# Altium schematic sheet S06_Metering_RevF.SchDoc
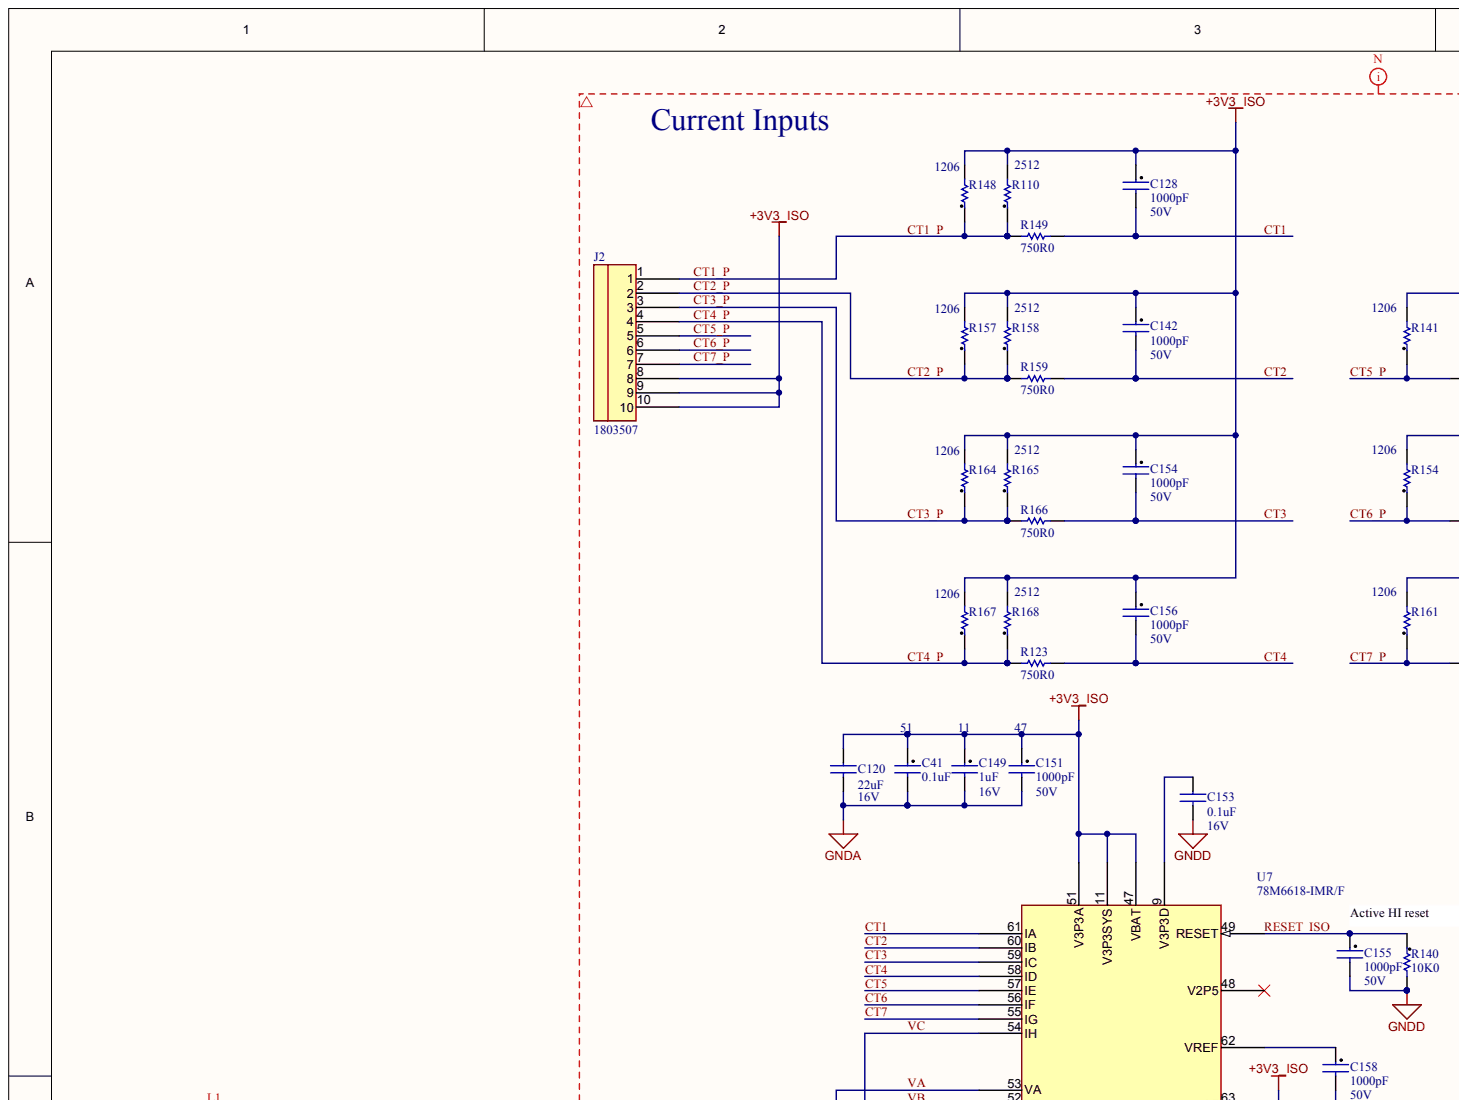
[diagram p0: S06_Metering_RevF.SchDoc, top left]
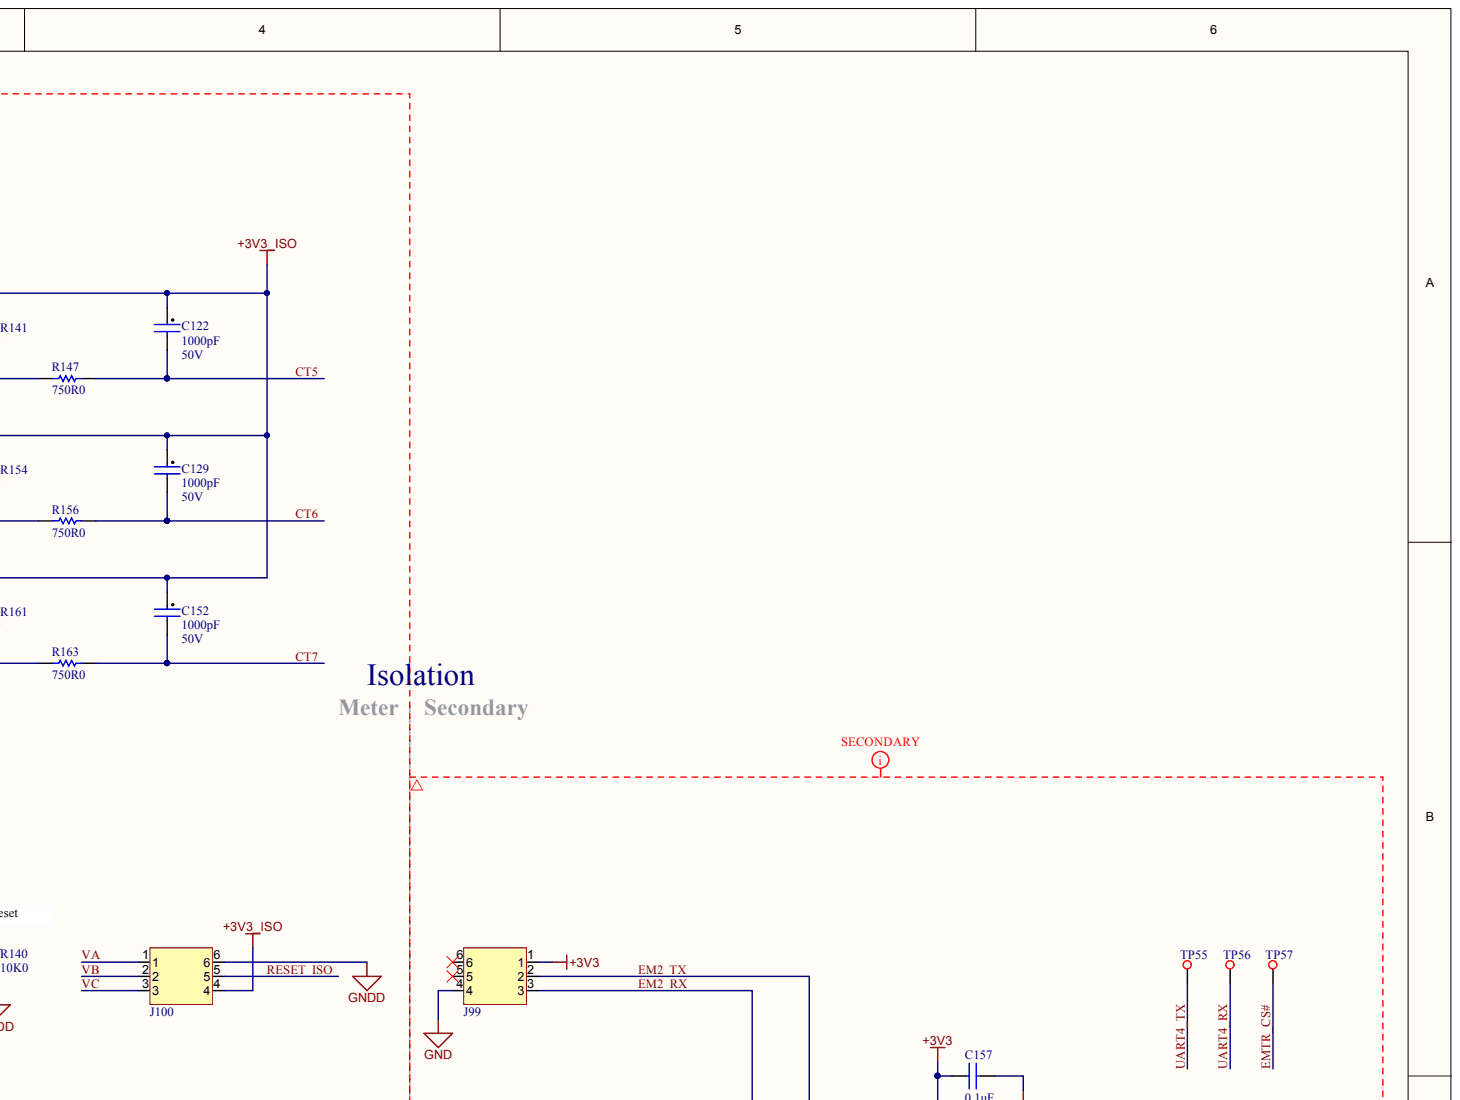
[diagram p1: S06_Metering_RevF.SchDoc, top right]
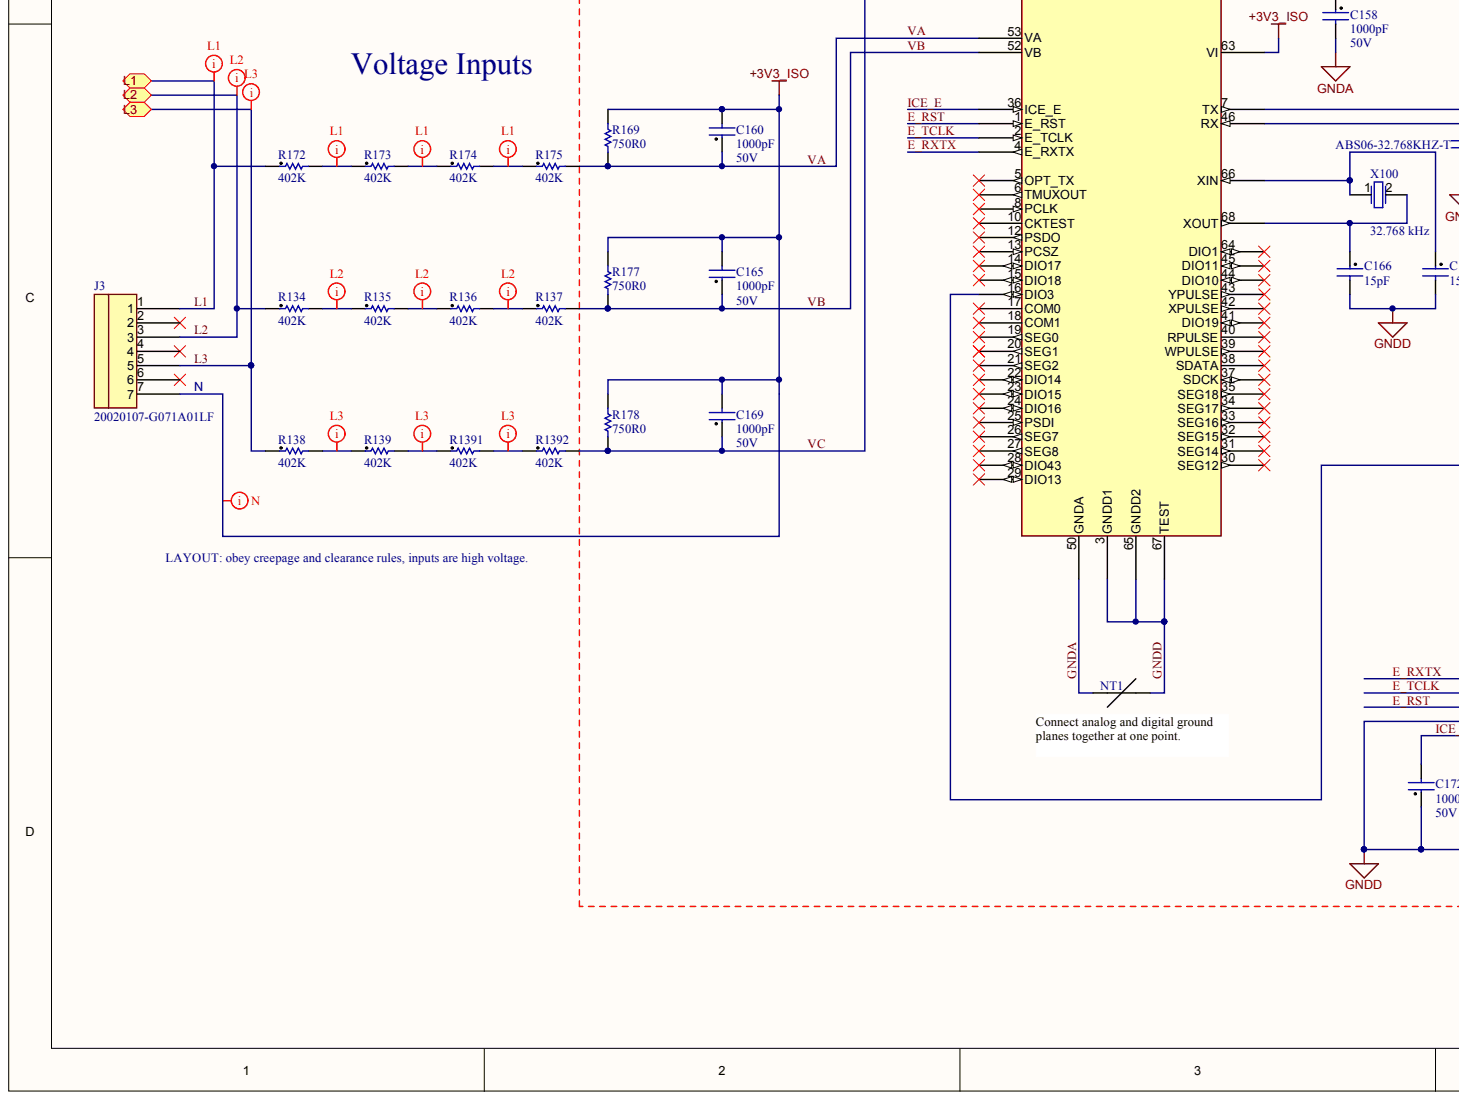
[diagram p2: S06_Metering_RevF.SchDoc, bottom left]
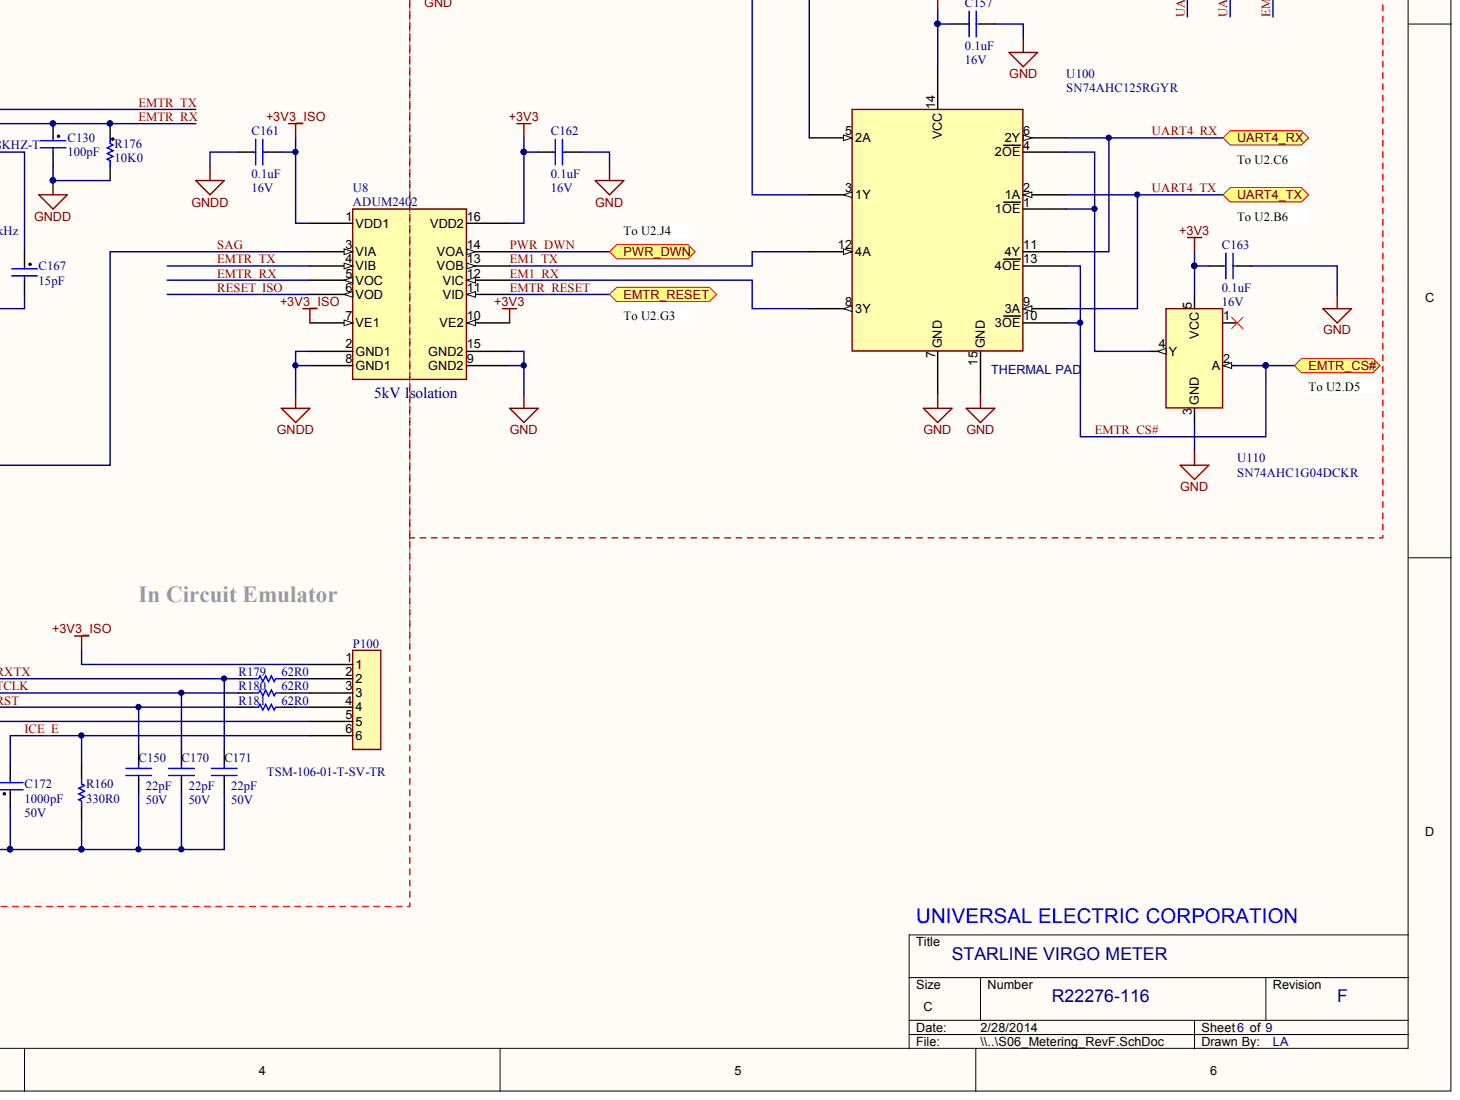
[diagram p3: S06_Metering_RevF.SchDoc, bottom right]

## Parts
C41  0.1uF
C120  Voltage: 16V  (hidden comment: 22uF)
C122  Voltage: 50V  (hidden comment: 1000pF)
C128  Voltage: 50V  (hidden comment: 1000pF)
C129  Voltage: 50V  (hidden comment: 1000pF)
C130  100pF
C142  Voltage: 50V  (hidden comment: 1000pF)
C149  Voltage-Rated: 16V  (hidden comment: 1uF)
C150  Voltage: 50V  (hidden comment: 22pF)
C151  Voltage: 50V  (hidden comment: 1000pF)
C152  Voltage: 50V  (hidden comment: 1000pF)
C153  value 0.1uF  Voltage: 16V  (hidden comment: CAP CER 0.1uF X5R 10% 16V SM_0402)
C154  Voltage: 50V  (hidden comment: 1000pF)
C155  Voltage: 50V  (hidden comment: 1000pF)
C156  Voltage: 50V  (hidden comment: 1000pF)
C157  value 0.1uF  (hidden comment: CAP CER 0.1uF X5R 10% 16V SM_0402)
C158  Voltage: 50V  (hidden comment: 1000pF)
C160  Voltage: 50V  (hidden comment: 1000pF)
C161  value 0.1uF  Voltage: 16V  (hidden comment: CAP CER 0.1uF X5R 10% 16V SM_0402)
C162  value 0.1uF  Voltage: 16V  (hidden comment: CAP CER 0.1uF X5R 10% 16V SM_0402)
C163  value 0.1uF  (hidden comment: CAP CER 0.1uF X5R 10% 16V SM_0402)
C165  Voltage: 50V  (hidden comment: 1000pF)
C166  15pF
C167  15pF
C169  Voltage: 50V  (hidden comment: 1000pF)
C170  Voltage: 50V  (hidden comment: 22pF)
C171  Voltage: 50V  (hidden comment: 22pF)
C172  Voltage: 50V  (hidden comment: 1000pF)
J2  value 1803507  MFG_PART_NO: 1803507  (hidden comment: TERM BLOCK PLUGGABLE 3.81MM 10P)
J3  20020107-G071A01LF
J99  A3A-6DA-2SV(71)  (value not drawn)
J100  A3A-6DA-2SV(71)  (value not drawn)
NT1  Net Tie  (value not drawn)
P100  TSM-106-01-T-SV-TR
R110  Package: 2512
R123  value 750R0  (hidden comment: RES 750R0 1/10W 1% SM_0603)
R134  402K
R135  402K
R136  402K
R137  402K
R138  402K
R139  402K
R140  10K0
R141  Package: 1206  (hidden comment: 5R76)
R147  value 750R0  (hidden comment: RES 750R0 1/10W 1% SM_0603)
R148  Package: 1206  (hidden comment: 5R76)
R149  value 750R0  (hidden comment: RES 750R0 1/10W 1% SM_0603)
R154  Package: 1206  (hidden comment: 5R76)
R156  value 750R0  (hidden comment: RES 750R0 1/10W 1% SM_0603)
R157  Package: 1206  (hidden comment: 5R76)
R158  Package: 2512
R159  value 750R0  (hidden comment: RES 750R0 1/10W 1% SM_0603)
R160  330R0
R161  Package: 1206  (hidden comment: 5R76)
R163  value 750R0  (hidden comment: RES 750R0 1/10W 1% SM_0603)
R164  Package: 1206  (hidden comment: 5R76)
R165  Package: 2512
R166  value 750R0  (hidden comment: RES 750R0 1/10W 1% SM_0603)
R167  Package: 1206  (hidden comment: 5R76)
R168  Package: 2512
R169  750R0
R172  402K
R173  402K
R174  402K
R175  402K
R176  10K0
R177  750R0
R178  750R0
R179  value 62R0
R180  value 62R0
R181  value 62R0
R1391  402K
R1392  402K
TP55  =VALUE
TP56  =VALUE
TP57  =VALUE
U7  value 78M6618-IMR/F  MFG_PART_NO: 78M6618-IMR/F  TYPE: IC  [QFN40P800X800X85-68N]  (hidden comment: Meter IC 78M6618-IMR/F)
U8  ADUM2402
U100  SN74AHC125RGYR
U110  SN74AHC1G04DCKR
X100  32.768 kHz

## Ports
EMTR_CS#, EMTR_RESET, L1, L2, L3, PWR_DWN, UART4_RX, UART4_TX

## Nets
+3V3: C157.1 C162.1 C163.1 J99.1 U8.10(VE2) U8.16(VDD2) U100.14(VCC) U110.5(VCC)
+3V3_ISO: C41.1 C120.1 C122.1 C128.1 C129.1 C142.1 C149.1 C151.1 C152.1 C154.1 C156.1 C160.2 C161.2 C165.2 C169.2 J2.8 J2.9 J2.10 J3.7 J100.4 P100.1 R110.2 R141.2 R148.2 R154.2 R157.2 R158.2 R161.2 R164.2 R165.2 R167.2 R168.2 R169.1 R177.1 R178.1 U7.11(V3P3SYS) U7.47(VBAT) U7.51(V3P3A) U7.63(VI) U8.1(VDD1) U8.7(VE1)
GND: C157.2 C162.2 C163.2 J99.4 U8.9(GND2) U8.15(GND2) U100.7(GND) U100.15(GND) U110.3(GND)
CT1: C128.2 R149.1 U7.61(IA)
CT1_P: J2.1 R110.1 R148.1 R149.2
CT2: C142.2 R159.1 U7.60(IB)
CT2_P: J2.2 R157.1 R158.1 R159.2
CT3: C154.2 R166.1 U7.59
CT3_P: J2.3 R164.1 R165.1 R166.2
CT4: C156.2 R123.1 U7.58(ID)
CT4_P: J2.4 R123.2 R167.1 R168.1
CT5: C122.2 R147.1 U7.57(IE)
CT5_P: J2.5 R141.1 R147.2
CT6: C129.2 R156.1 U7.56(IF)
CT6_P: J2.6 R154.1 R156.2
CT7: C152.2 R163.1 U7.55(IG)
CT7_P: J2.7 R161.1 R163.2
E_RST: C150.2 R181.2 U7.1(E_RST)
E_RXTX: C171.2 R179.2 U7.4(E_RXTX)
E_TCLK: C170.2 R180.2 U7.2(E_TCLK)
EM1_RX: U8.12(VIC) U100.8(3Y)
EM1_TX: U8.13(VOB) U100.12(4A)
EM2_RX: J99.3 U100.3(1Y)
EM2_TX: J99.2 U100.5(2A)
EMTR_CS#: TP57.1 U100.10(3OE) U100.13(4OE) U110.2(A) ; port EMTR_CS#
EMTR_RESET: U8.11(VID) ; port EMTR_RESET
EMTR_RX: C130.1 R176.1 U7.46(RX) U8.5(VOC)
EMTR_TX: U7.7(TX) U8.4(VIB)
GNDA: C41.2 C120.2 C149.2 C151.2 C158.2 NT1.1 U7.50(GNDA)
GNDD: C130.2 C150.1 C153.2 C155.2 C161.1 C166.2 C167.2 C170.1 C171.1 C172.1 J100.6 NT1.2 P100.5 R140.2 R160.1 R176.2 U7.3(GNDD1) U7.65(GNDD2) U7.67(TEST) U8.2(GND1) U8.8(GND1)
ICE_E: C172.2 P100.6 R160.2 U7.36(ICE_E)
L1: J3.1 R172.1 ; port L1
L2: J3.3 R134.1 ; port L2
L3: J3.5 R138.1 ; port L3
PWR_DWN: U8.14(VOA) ; port PWR_DWN
RESET_ISO: C155.1 J100.5 R140.1 U7.49(RESET) U8.6(VOD)
SAG: U7.16(DIO3) U8.3(VIA)
UART4_RX: TP56.1 U100.6(2Y) U100.11(4Y) ; port UART4_RX
UART4_TX: TP55.1 U100.2(1A) U100.9(3A) ; port UART4_TX
VA: C160.1 J100.1 R169.2 R175.2 U7.53(VA)
VB: C165.1 J100.2 R137.2 R177.2 U7.52(VB)
VC: C169.1 J100.3 R178.2 R1392.2 U7.54(IH)
NetC153_1: C153.1 U7.9(V3P3D)
NetC158_1: C158.1 U7.62(VREF)
NetC166_1: C166.1 U7.68(XOUT) X100.2
NetC167_1: C167.1 U7.66(XIN) X100.1
NetP100_2: P100.2 R179.1
NetP100_3: P100.3 R180.1
NetP100_4: P100.4 R181.1
NetR134_2: R134.2 R135.1
NetR135_2: R135.2 R136.1
NetR136_2: R136.2 R137.1
NetR138_2: R138.2 R139.1
NetR139_2: R139.2 R1391.1
NetR172_2: R172.2 R173.1
NetR173_2: R173.2 R174.1
NetR174_2: R174.2 R175.1
NetR1391_2: R1391.2 R1392.1
NetU100_1: U100.1(1OE) U100.4(2OE) U110.4(Y)
N00292:
N00293:

Not connected: J3 2 4 6; J99 5 6; U7 5(OPT_TX) 6(TMUXOUT) 8(PCLK) 10(CKTEST) 12(PSDO) 13(PCSZ) 14(DIO17) 15(DIO18) 17(COM0) 18(COM1) 19(SEG0) 20(SEG1) 21(SEG2) 22(DIO14) 23(DIO15) 24(DIO16) 25(PSDI) 26(SEG7) 27(SEG8) 28(DIO43) 29(DIO13) 30(SEG12) 31(SEG14) 32(SEG15) 33(SEG16) 34(SEG17) 35(SEG18) 37(SDCK) 38(SDATA) 39(WPULSE) 40(RPULSE) 41(DIO19) 42(XPULSE) 43(YPULSE) 44(DIO10) 45(DIO11) 48(V2P5) 64(DIO1); U110 1

## Library data of the parts
C41 = (no symbol name): Manufacturer P/N: GRM155R71C104KA88D; Manufacturer: Murata; Voltage-Rated: 16V; Tolerance: 0.1; Package: SM_0402; Capacitance: 0.1uF; MFG_PART_NO: GRM155R71C104KA88D
C120 = (no symbol name): MFG_PART_NO: C2012X5R1C226K; MFG: TDK; Value: 22uF
C122 C128 C129 C142 C151 C152 C154-C156 C158 C160 C165 C169 C172 = (no symbol name): MFG_PART_NO: C1005X7R1H102K050BA; MFG: Yageo; Value: 1000pF
C130 = (no symbol name): Manufacturer P/N: C0402C101K3GACTU; Manufacturer: Kemet; Voltage-Rated: 25V; Tolerance: 0.1; Package: SM_0402; Capacitance: 100pF
C149 = (no symbol name): Manufacturer P/N: C2012X7R1C105K; Manufacturer: TDK; Tolerance: 0.2; Package: SM_0805; Capacitance: 1uF
C150 C170 C171 = (no symbol name): MFG_PART_NO: CC0402JRNPO9BN220; MFG: Yageo; Value: 22pF
C153 C157 C161-C163 = (no symbol name): MFG_PART_NO: GRM155R71C104KA88D; MFG: Murata
  C157 C163: Voltage: 16V
C166 C167 = (no symbol name): Manufacturer P/N: C1005C0G1H150J; Manufacturer: TDK; Voltage-Rated: 50V; Tolerance: 0.05; Package: SM_0402; Capacitance: 15pF
J2 = (no symbol name): MFG: Phoenix Contact; TYPE: Connector
J3 = (no symbol name): NUV_PART_NO: UEC01-0077; LEVEL: Level_1; MFG: FCI; NUV_DESCRIPTION: TERM BLOCK 7POS 5.00MM; NOSTUFF: *; MFG_PART_NO: 20020107-G071A01LF; TYPE: Connector; VALUE: 20020107-G071A01LF; PACKAGE: Thru-Hole; ROHS: RoHS; LAST_UPDATE: 7/26/2012; Created By: K Desrochers
J99 J100 = (no symbol name): MFG: Hirose Electric Co Ltd; MFG_PART_NO: A3A-6DA-2SV(71); VALUE: A3A-6DA-2SV(71)
P100 = (no symbol name): MFG: Samtec; VALUE: TSM-106-01-T-SV-TR; MFG_PART_NO: TSM-106-01-T-SV-TR
R110 R158 R165 R168 = (no symbol name): Manufacturer P/N: BLU2512-5R76-BT25W; Manufacturer: RCD; RESISTANCE: 5R76; Power: 1W; Tolerance: 0.001; Part Number: BLU2512-5R76-BT25W; Type: Resistor; Comment: =DNP
R123 R147 R149 R156 R159 R163 R166 = (no symbol name): MFG_PART_NO: CRCW0603750RFKEA; MFG: Vishay
R134-R139 R172-R175 R1391 R1392 = (no symbol name): Part Number: ERA-8AEB4023V; Manufacturer: Panasonic; Power: 1/4W; Tolerance: 0.001; Type: Resistor; RESISTANCE: 402K; Manufacturer P/N: ERA-8AEB4023V; Package: SMT
R140 R176 = (no symbol name): Part Number: CRCW040210K0FKED; Manufacturer: Vishay; Power: 1/16W; Tolerance: 0.01; RESISTANCE: 10K0; Manufacturer P/N: CRCW040210K0FKED; Package: SMT; Type: Resistor
R141 R154 R161 = (no symbol name): Part Number: BLU1206-5R76-BT25W; Manufacturer: RCD; Power: 1/4W; Tolerance: 0.1; RESISTANCE: 5R76; Manufacturer P/N: BLU1206-5R76-BT25W; Type: Resistor
R148 R157 R164 R167 = (no symbol name): Manufacturer P/N: BLU1206-5R76-BT25W; Manufacturer: RCD; Power: 1/4W; Tolerance: 0.1; RESISTANCE: 5R76; Part Number: BLU1206-5R76-BT25W; Type: Resistor
R160 = (no symbol name): MFG_PART_NO: CRCW0402330RJNED; MFG: Vishay; VALUE: 330R0
R169 R177 R178 = (no symbol name): MFG_PART_NO: BLU0603-7500-DT25W; MFG: RCD; VALUE: 750R0
R179-R181 = (no symbol name): MFG_PART_NO: CRCW040262R0JNED; MFG: Vishay / Dale
TP55-TP57 = (no symbol name): NUV_PART_NO: UEC01-0068; SYM_LVL: LEVEL1; LEVEL: Level_1; NUV_DESCRIPTION: Test Point; ROOM: *; NOSTUFF: NOSTUFF; TYPE: Test Point; PACKAGE: SMT; ROHS: RoHS; LAST_UPDATE: 6/21/2012; Created By: K Desrochers
U7 = (no symbol name): MFG: Maxim
U8 = (no symbol name): NUV_PART_NO: UEC01-0118; MFG_PART_NO: ADUM2402ARWZ-RL; LEVEL: Level_1; PCBLIB: UEC01.PcbLib; MFG: Analog Devices; VALUE: ADUM2402ARWZ-RL; NUV_DESCRIPTION: IC ISOLATOR DIGITAL QUAD 16SOIC; TYPE: IC; PACKAGE: SMT; ROHS: RoHS; LAST_UPDATE: 8/2/2012; Created By: K Desrochers
U100 = (no symbol name): MFG_PART_NO: TI SN74AHC125RGYR; MFG: Analog Devices; VALUE: TI SN74AHC125RGYR
U110 = (no symbol name): MFG: Analog Devices; MFG_PART_NO: SN74AHC1G04DCKR; VALUE: SN74AHC1G04DCKR
X100 = (no symbol name): MFG_PART_NO: ABS06-32.768KHZ-T; MFG: Abracon; VALUE: 32.768 kHz
